# Altium schematic sheet pmic_left.SchDoc(pmic_left)
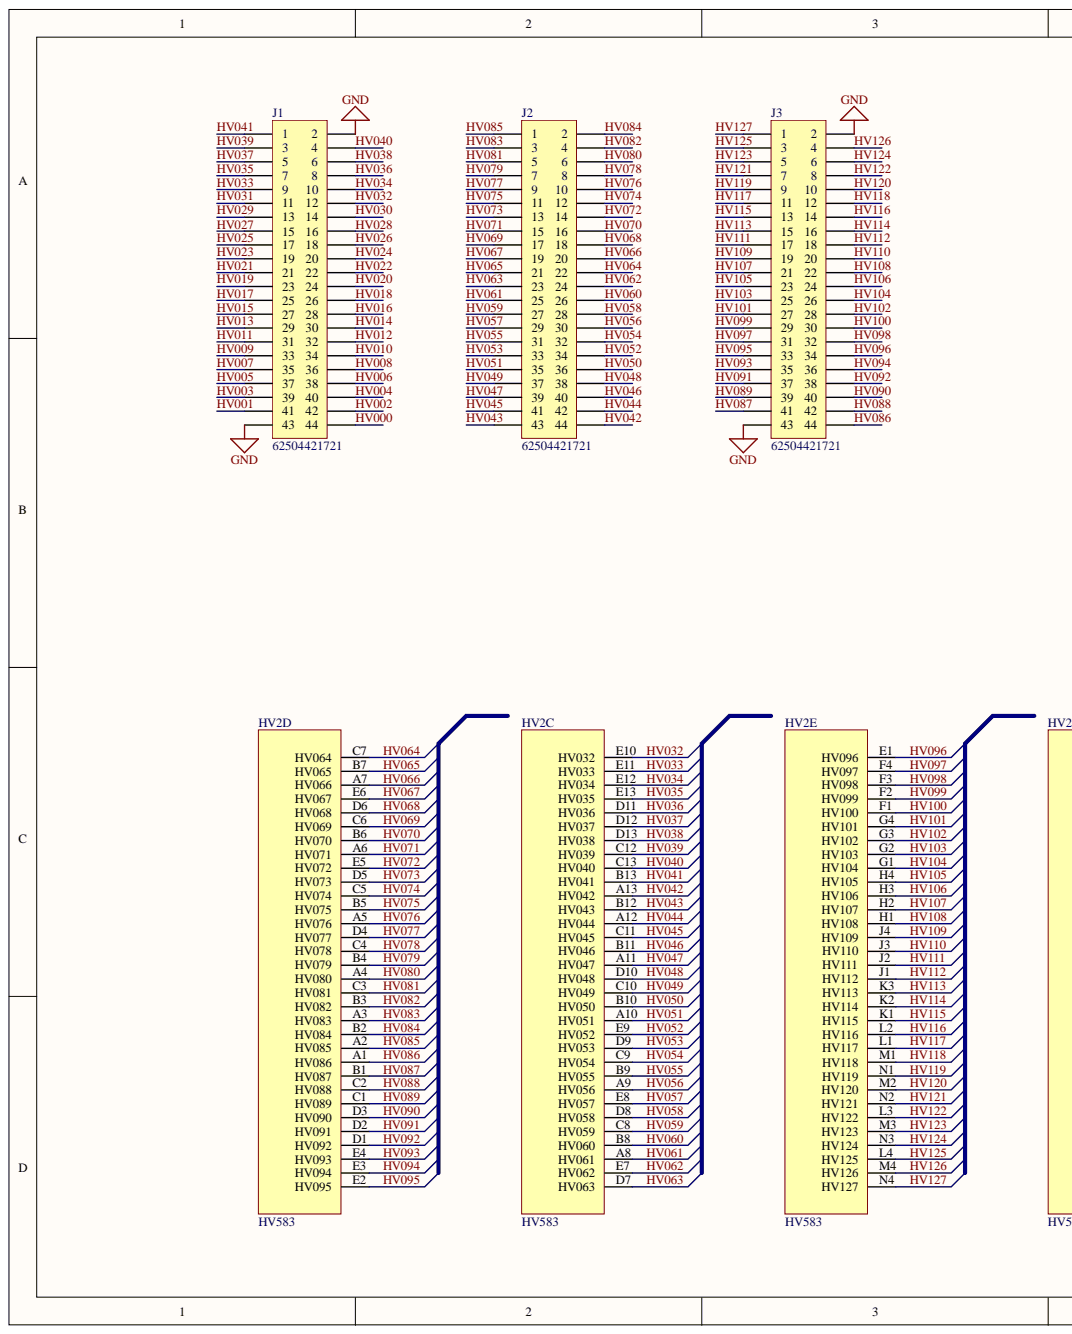
[diagram p0: pmic_left.SchDoc, left]
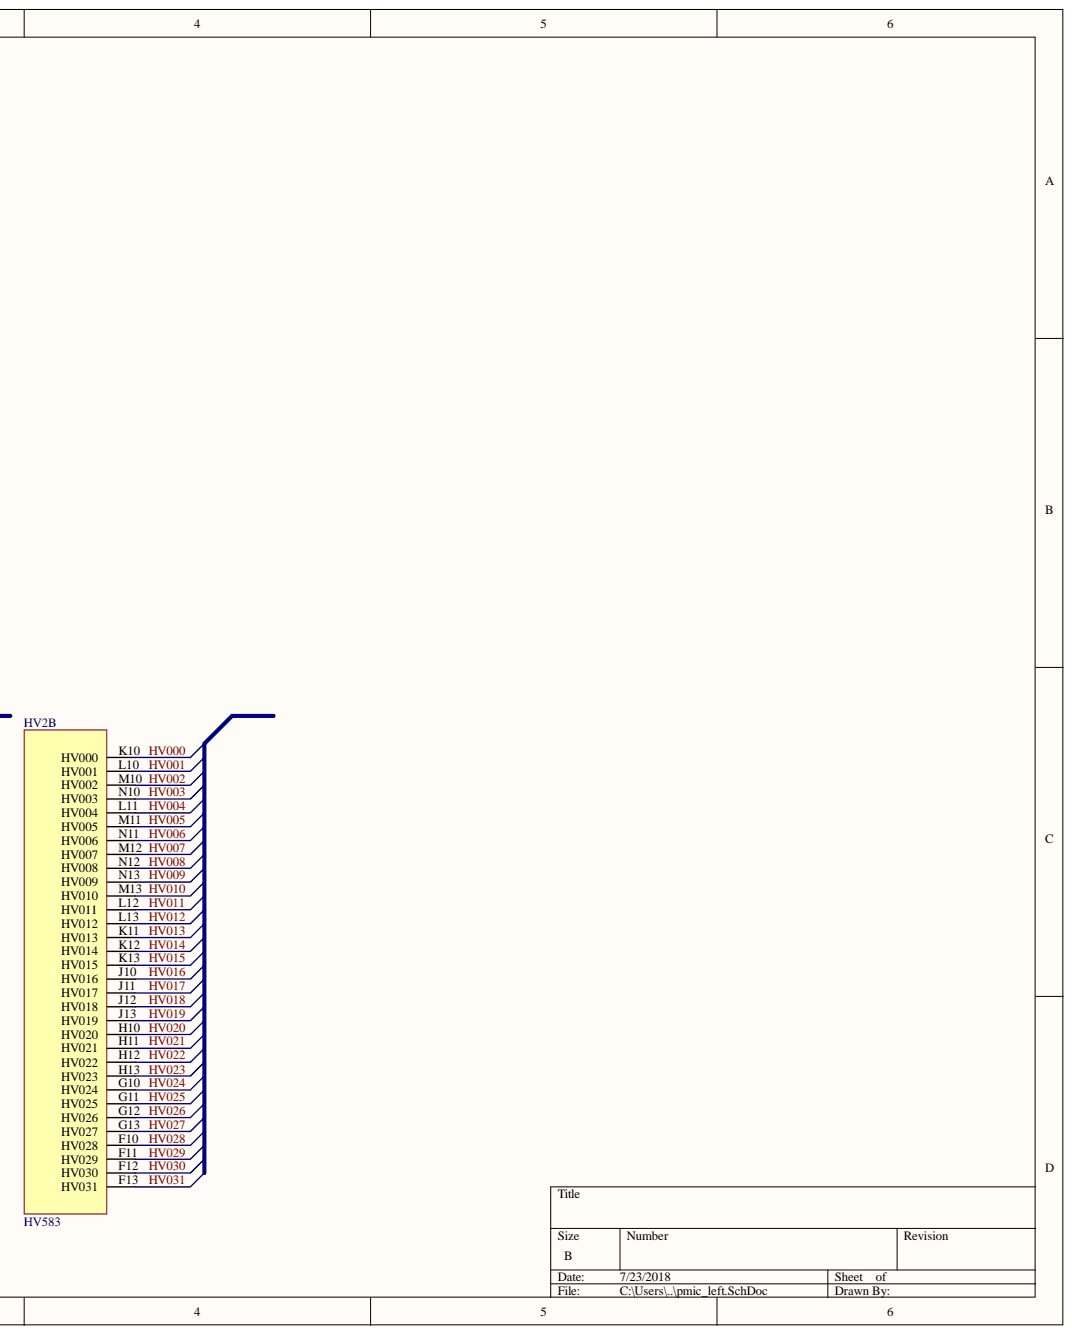
[diagram p1: pmic_left.SchDoc, right]

## Parts
HV2  HV583  [BGA169x13_0.26balls]  (parts B,C,D,E)
J1  62504421721  [62504421721]
J2  62504421721  [62504421721]
J3  62504421721  [62504421721]

## Nets
GND: J1.2 J1.43 J3.2 J3.43
HV000: HV2.K10(HV000) J1.44
HV001: HV2.L10(HV001) J1.41
HV002: HV2.M10(HV002) J1.42
HV003: HV2.N10(HV003) J1.39
HV004: HV2.L11(HV004) J1.40
HV005: HV2.M11(HV005) J1.37
HV006: HV2.N11(HV006) J1.38
HV007: HV2.M12(HV007) J1.35
HV008: HV2.N12(HV008) J1.36
HV009: HV2.N13(HV009) J1.33
HV010: HV2.M13(HV010) J1.34
HV011: HV2.L12(HV011) J1.31
HV012: HV2.L13(HV012) J1.32
HV013: HV2.K11(HV013) J1.29
HV014: HV2.K12(HV014) J1.30
HV015: HV2.K13(HV015) J1.27
HV016: HV2.J10(HV016) J1.28
HV017: HV2.J11(HV017) J1.25
HV018: HV2.J12(HV018) J1.26
HV019: HV2.J13(HV019) J1.23
HV020: HV2.H10(HV020) J1.24
HV021: HV2.H11(HV021) J1.21
HV022: HV2.H12(HV022) J1.22
HV023: HV2.H13(HV023) J1.19
HV024: HV2.G10(HV024) J1.20
HV025: HV2.G11(HV025) J1.17
HV026: HV2.G12(HV026) J1.18
HV027: HV2.G13(HV027) J1.15
HV028: HV2.F10(HV028) J1.16
HV029: HV2.F11(HV029) J1.13
HV030: HV2.F12(HV030) J1.14
HV031: HV2.F13(HV031) J1.11
HV032: HV2.E10(HV032) J1.12
HV033: HV2.E11(HV033) J1.9
HV034: HV2.E12(HV034) J1.10
HV035: HV2.E13(HV035) J1.7
HV036: HV2.D11(HV036) J1.8
HV037: HV2.D12(HV037) J1.5
HV038: HV2.D13(HV038) J1.6
HV039: HV2.C12(HV039) J1.3
HV040: HV2.C13(HV040) J1.4
HV041: HV2.B13(HV041) J1.1
HV042: HV2.A13(HV042) J2.44
HV043: HV2.B12(HV043) J2.43
HV044: HV2.A12(HV044) J2.42
HV045: HV2.C11(HV045) J2.41
HV046: HV2.B11(HV046) J2.40
HV047: HV2.A11(HV047) J2.39
HV048: HV2.D10(HV048) J2.38
HV049: HV2.C10(HV049) J2.37
HV050: HV2.B10(HV050) J2.36
HV051: HV2.A10(HV051) J2.35
HV052: HV2.E9(HV052) J2.34
HV053: HV2.D9(HV053) J2.33
HV054: HV2.C9(HV054) J2.32
HV055: HV2.B9(HV055) J2.31
HV056: HV2.A9(HV056) J2.30
HV057: HV2.E8(HV057) J2.29
HV058: HV2.D8(HV058) J2.28
HV059: HV2.C8(HV059) J2.27
HV060: HV2.B8(HV060) J2.26
HV061: HV2.A8(HV061) J2.25
HV062: HV2.E7(HV062) J2.24
HV063: HV2.D7(HV063) J2.23
HV064: HV2.C7(HV064) J2.22
HV065: HV2.B7(HV065) J2.21
HV066: HV2.A7(HV066) J2.20
HV067: HV2.E6(HV067) J2.19
HV068: HV2.D6(HV068) J2.18
HV069: HV2.C6(HV069) J2.17
HV070: HV2.B6(HV070) J2.16
HV071: HV2.A6(HV071) J2.15
HV072: HV2.E5(HV072) J2.14
HV073: HV2.D5(HV073) J2.13
HV074: HV2.C5(HV074) J2.12
HV075: HV2.B5(HV075) J2.11
HV076: HV2.A5(HV076) J2.10
HV077: HV2.D4(HV077) J2.9
HV078: HV2.C4(HV078) J2.8
HV079: HV2.B4(HV079) J2.7
HV080: HV2.A4(HV080) J2.6
HV081: HV2.C3(HV081) J2.5
HV082: HV2.B3(HV082) J2.4
HV083: HV2.A3(HV083) J2.3
HV084: HV2.B2(HV084) J2.2
HV085: HV2.A2(HV085) J2.1
HV086: HV2.A1(HV086) J3.44
HV087: HV2.B1(HV087) J3.41
HV088: HV2.C2(HV088) J3.42
HV089: HV2.C1(HV089) J3.39
HV090: HV2.D3(HV090) J3.40
HV091: HV2.D2(HV091) J3.37
HV092: HV2.D1(HV092) J3.38
HV093: HV2.E4(HV093) J3.35
HV094: HV2.E3(HV094) J3.36
HV095: HV2.E2(HV095) J3.33
HV096: HV2.E1(HV096) J3.34
HV097: HV2.F4(HV097) J3.31
HV098: HV2.F3(HV098) J3.32
HV099: HV2.F2(HV099) J3.29
HV100: HV2.F1(HV100) J3.30
HV101: HV2.G4(HV101) J3.27
HV102: HV2.G3(HV102) J3.28
HV103: HV2.G2(HV103) J3.25
HV104: HV2.G1(HV104) J3.26
HV105: HV2.H4(HV105) J3.23
HV106: HV2.H3(HV106) J3.24
HV107: HV2.H2(HV107) J3.21
HV108: HV2.H1(HV108) J3.22
HV109: HV2.J4(HV109) J3.19
HV110: HV2.J3(HV110) J3.20
HV111: HV2.J2(HV111) J3.17
HV112: HV2.J1(HV112) J3.18
HV113: HV2.K3(HV113) J3.15
HV114: HV2.K2(HV114) J3.16
HV115: HV2.K1(HV115) J3.13
HV116: HV2.L2(HV116) J3.14
HV117: HV2.L1(HV117) J3.11
HV118: HV2.M1(HV118) J3.12
HV119: HV2.N1(HV119) J3.9
HV120: HV2.M2(HV120) J3.10
HV121: HV2.N2(HV121) J3.7
HV122: HV2.L3(HV122) J3.8
HV123: HV2.M3(HV123) J3.5
HV124: HV2.N3(HV124) J3.6
HV125: HV2.L4(HV125) J3.3
HV126: HV2.M4(HV126) J3.4
HV127: HV2.N4(HV127) J3.1
N00242:
N00243:
N00244:
N00245:

## Library data of the parts
HV2 = HV583: 128bit high voltage shift register; Library Name: Microchip_HV58x.SchLib; Component Kind: Standard
J1-J3 = 62504421721: WR-BHD 2.00mm Male Angled Box Header, 44p; Pin Number: 44; Published Date: June 2017; Pitch: 2.00 mm; Part Number: 62504421721; Library Name: ALTIUM-BHD_2_00_MALE_ANGLED_BOX_HEADER_6250XX21721-rev17a.IntLib; ComponentLink2URL: http://katalog.we-online.de/em/datasheet/6250xx21721.pdf; ComponentLink2Description: Datasheet; ComponentLink1URL: http://www.we-online.de; ComponentLink1Description: Manufacturer; Component Kind: Standard; Publisher: Wurth Elektronik
